# Altium schematic sheet DUT-PowerSupplyMB-Rev2b.SchDoc(DUT-PowerSupplyMB-Rev2b)
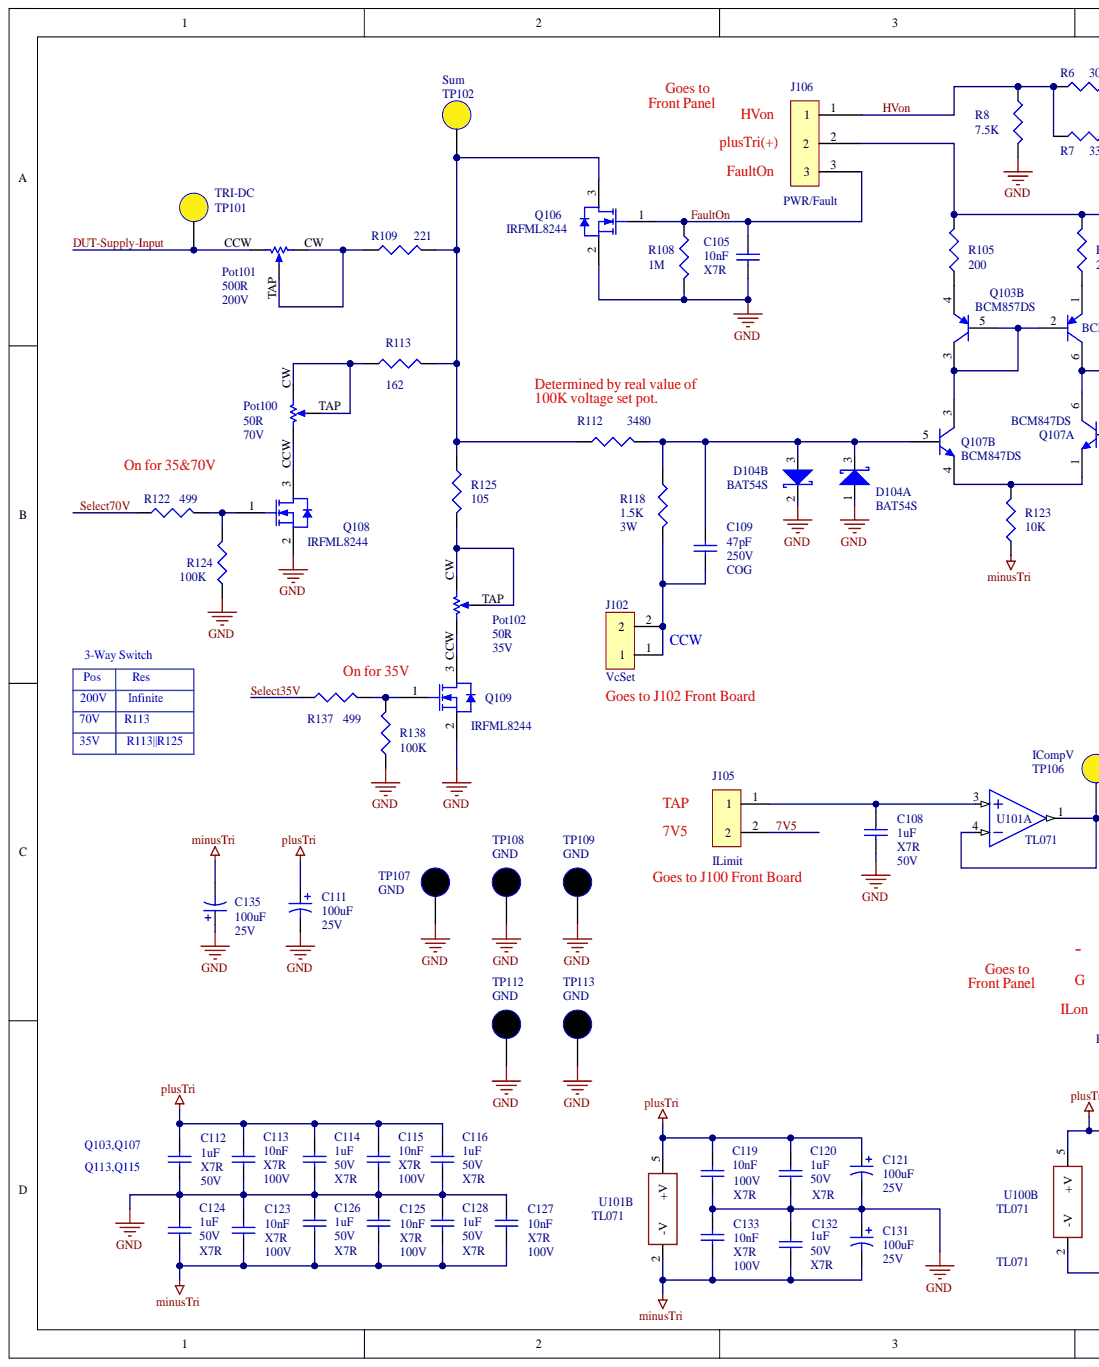
[diagram p0: DUT-PowerSupplyMB-Rev2b.SchDoc, left]
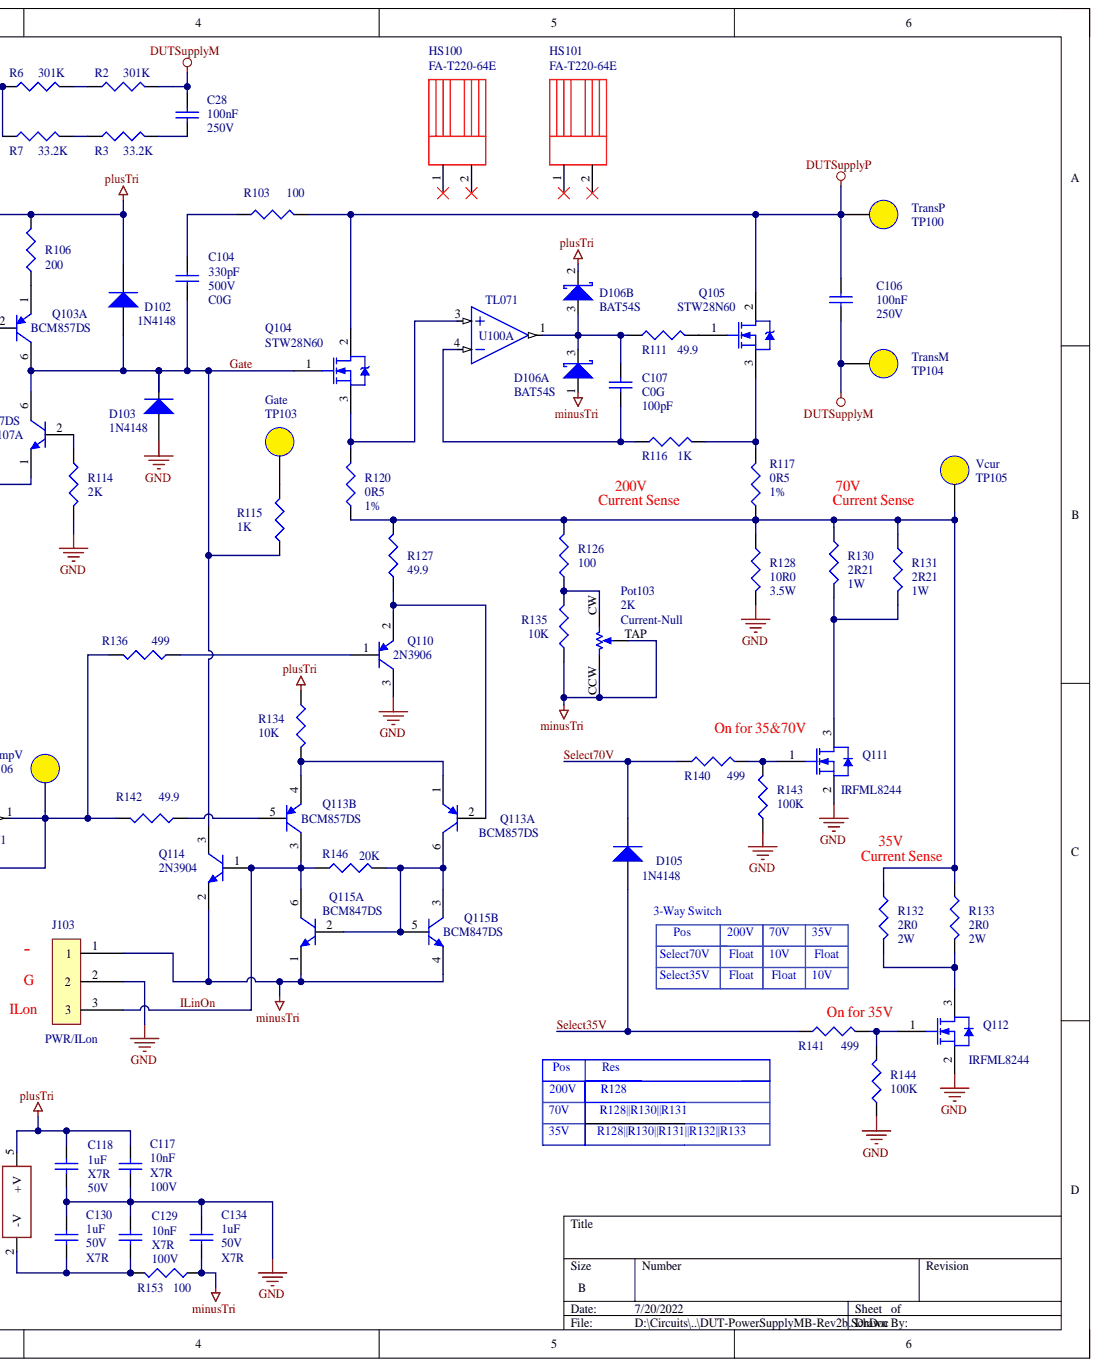
[diagram p1: DUT-PowerSupplyMB-Rev2b.SchDoc, right]

## Parts
C28  Capacitance: 100nF  Voltage Rating: 250V  [CAPC3216M]  (hidden comment: 0.1uF)
C104  330pF  Dielectric: C0G  Voltage Rating: 500V  [CAPC3216M]
C105  10nF  Dielectric: X7R  [CAPC2012M]
C106  Capacitance: 100nF  Voltage Rating: 250V  [CAPC3216M]  (hidden comment: 0.1uF)
C107  100pF  Dielectric: C0G  [CAPC2012M]
C108  1uF  Dielectric: X7R  Voltage: 50V  [CAPC2012M]
C109  47pF  Dialectriv: COG  Voltage Rating: 250V  [CAPC2012M]
C111  100uF  Voltage: 25V  [CAPPR2-5x11]
C112  1uF  Dielectric: X7R  Voltage: 50V  [CAPC2012M]
C113  10nF  Dielectric: X7R  Voltage: 100V  [CAPC2012M]
C114  1uF  Dielectric: X7R  Voltage: 50V  [CAPC2012M]
C115  10nF  Dielectric: X7R  [CAPC2012M]
C116  1uF  Dielectric: X7R  Voltage: 50V  [CAPC2012M]
C117  10nF  Dielectric: X7R  Voltage: 100V  [CAPC2012M]
C118  1uF  Dielectric: X7R  Voltage: 50V  [CAPC2012M]
C119  10nF  Dielectric: X7R  Voltage: 100V  [CAPC2012M]
C120  1uF  Dielectric: X7R  Voltage: 50V  [CAPC2012M]
C121  100uF  Voltage: 25V  [CAPPR2-5x11]
C123  10nF  Dielectric: X7R  Voltage: 100V  [CAPC2012M]
C124  1uF  Dielectric: X7R  Voltage: 50V  [CAPC2012M]
C125  10nF  Dielectric: X7R  [CAPC2012M]
C126  1uF  Dielectric: X7R  Voltage: 50V  [CAPC2012M]
C127  10nF  Dielectric: X7R  Voltage: 100V  [CAPC2012M]
C128  1uF  Dielectric: X7R  Voltage: 50V  [CAPC2012M]
C129  10nF  Dielectric: X7R  [CAPC2012M]
C130  1uF  Dielectric: X7R  Voltage: 50V  [CAPC2012M]
C131  100uF  Voltage: 25V  [CAPPR2-5x11]
C132  1uF  Dielectric: X7R  Voltage: 50V  [CAPC2012M]
C133  10nF  Dielectric: X7R  Voltage: 100V  [CAPC2012M]
C134  1uF  Dielectric: X7R  Voltage: 50V  [CAPC2012M]
C135  100uF  Voltage: 25V  [CAPPR2-5x11]
D102  1N4148  [SOD123]
D103  1N4148  [SOD123]
D104  BAT54S  [SOT23-3M]  (parts A,B)
D105  1N4148  [SOD123]
D106  BAT54S  [SOT23-3M]  (parts A,B)
HS100  value FA-T220-64E  Manufacturer Part Number: FA-T220-64E  [FA-T220]  (hidden comment: 2 Pin Heat Sink)
HS101  value FA-T220-64E  Manufacturer Part Number: FA-T220-64E  [FA-T220]  (hidden comment: 2 Pin Heat Sink)
J102  VcSet  [DTA-100-2]
J103  PWR/ILon  [DTA-100-3]
J105  ILimit  [DTA-100-2]
J106  PWR/Fault  [DTA-100-3]
Pot100  70V  value 50R  Resistance: 50R  [7MMSq-CCW]
Pot101  200V  value 500R  Resistance: 500R  [7MMSq-CCW]
Pot102  35V  value 50R  Resistance: 50R  [7MMSq-CCW]
Pot103  Current-Null  value 2K  [3306P-CCW]
Q103  BCM857DS  [SC-74]  (parts A,B)
Q104  STW28N60  [TO-247]
Q105  STW28N60  [TO-247]
Q106  IRFML8244  [SOT23-3M]
Q107  BCM847DS  [SC-74]  (parts A,B)
Q108  IRFML8244  [SOT23-3M]
Q109  IRFML8244  [SOT23-3M]
Q110  2N3906  [SOT23-3M]
Q111  IRFML8244  [SOT23-3M]
Q112  IRFML8244  [SOT23-3M]
Q113  BCM857DS  [SC-74]  (parts A,B)
Q114  2N3904  [SOT23-3M]
Q115  BCM847DS  [SC-74]  (parts A,B)
R2  value 301K  [RESC2012M]  (hidden comment: 226K)
R3  value 33.2K  [RESC3216M]  (hidden comment: 30.1K)
R6  value 301K  [RESC2012M]  (hidden comment: 226K)
R7  value 33.2K  [RESC3216M]  (hidden comment: 30.1K)
R8  7.5K  [RESC2012M]
R103  100  [RESC3216M]
R105  200  [RESC2012M]
R106  200  [RESC2012M]
R108  1M  [RESC2012M]
R109  value 221  [RESC3216M]  (hidden comment: 200)
R111  49.9  [RESC2012M]
R112  value 3480  [RESC3216M]  (hidden comment: 4.75K)
R113  value 162  [RESC3216M]  (hidden comment: 120)
R114  2K  [RESC2012M]
R115  1K  [RESC2012M]
R116  1K  [RESC2012M]
R117  0R5  Tolerance: 1%  [RESC6432M]
R118  1.5K  Power Rating: 3W  [RESC6432BM]
R120  0R5  Tolerance: 1%  [RESC6432M]
R122  499  [RESC2012M]
R123  10K  [RESC2012M]
R124  100K  [RESC2012M]
R125  value 105  [RESC3216M]  (hidden comment: 49.9)
R126  100  [RESC2012M]
R127  49.9  Resistance Tolerance: 1%  [RESC2012M]
R128  R  value 10R0  Power: 3.5W  [RESC6432BM]
R130  value 2R21  Power Rating: 1W  [RESC6432BM]  (hidden comment: 4R7)
R131  value 2R21  Power Rating: 1W  [RESC6432BM]  (hidden comment: 4R7)
R132  2R0  Power: 2W  [RESC6432BM]
R133  2R0  Power: 2W  [RESC6432BM]
R134  10K  [RESC2012M]
R135  10K  [RESC2012M]
R136  499  [RESC2012M]
R137  499  [RESC2012M]
R138  100K  [RESC2012M]
R140  499  [RESC2012M]
R141  499  [RESC2012M]
R142  49.9  [RESC2012M]
R143  100K  [RESC2012M]
R144  100K  [RESC2012M]
R146  20K  [RESC2012M]
R153  100  [RESC2012M]
TP100  TransP  [TP-.038]
TP101  TRI-DC  [TP-.038]
TP102  Sum  [TP-.038]
TP103  Gate  [TP-.038]
TP104  TransM  [TP-.038]
TP105  Vcur  [TP-.038]
TP106  ICompV  [TP-.038]
TP107  GND  [TP-.038]
TP108  GND  [TP-.038]
TP109  GND  [TP-.038]
TP112  GND  [TP-.038]
TP113  GND  [TP-.038]
U100  value TL071  [SOT95P300X130-5M]  (hidden comment: OPA197)  (parts A,B)
U101  TL071  [SOT95P300X130-5M]  (parts A,B)

## Nets
DUTSupplyM: C28.1 C106.2 R2.2 TP104.1
DUTSupplyP: C106.1 Q104.2 Q105.2 R103.2 TP100.1
GND: C105.2 C108.2 C111.2 C112.2 C113.2 C114.1 C115.1 C116.1 C117.2 C118.2 C119.2 C120.2 C121.2 C123.2 C124.2 C125.2 C126.2 C127.2 C128.2 C129.2 C130.2 C131.1 C132.2 C133.2 C134.2 C135.1 D103.1 D104.1 D104.2 J103.2 Q106.2 Q108.2 Q109.2 Q110.3 Q111.2 Q112.2 R8.1 R108.2 R114.2 R124.1 R128.2 R138.1 R143.1 R144.1 TP107.1 TP108.1 TP109.1 TP112.1 TP113.1
minusTri: C123.1 C124.1 C125.1 C126.1 C127.1 C128.1 C131.2 C132.1 C133.1 C134.1 C135.2 D106.1 J103.1 Pot103.CCW Pot103.TAP Q114.2 Q115.1 Q115.4 R123.2 R135.2 R153.2 U101.2(-V)
plusTri: C111.1 C112.1 C113.1 C114.2 C115.2 C116.2 C117.1 C118.1 C119.1 C120.1 C121.1 D102.2 D106.2 J106.2 R105.2 R106.2 R134.1 U100.5(+V) U101.5(+V)
7V5: J105.2
DUT-Supply-Input: Pot101.CCW TP101.1
FaultOn: C105.1 J106.3 Q106.1 R108.1
Gate: C104.2 D102.1 D103.2 Q103.6 Q104.1 Q107.6 Q114.3 R115.1
HVon: J106.1 R6.1 R7.1 R8.2
ILinOn: J103.3 Q113.3 Q114.1 Q115.6 R146.1
Select35V: D105.1 R137.1 R141.1
Select70V: D105.2 R122.1 R140.1
NetC28_2: C28.2 R3.2
NetC104_1: C104.1 R103.1
NetC107_1: C107.1 R116.1 U100.4
NetC107_2: C107.2 D106.3 R111.1 U100.1
NetC108_1: C108.1 J105.1 U101.3
NetC109_1: C109.1 D104.3 Q107.5 R112.2 R118.1
NetC109_2: C109.2 J102.1 J102.2 R118.2
NetC129_1: C129.1 C130.1 R153.1 U100.2(-V)
NetPot100_CCW: Pot100.CCW Q108.3
NetPot100_CW: Pot100.CW Pot100.TAP R113.1
NetPot101_CW: Pot101.CW Pot101.TAP R109.1
NetPot102_CCW: Pot102.CCW Q109.3
NetPot102_CW: Pot102.CW Pot102.TAP R125.2
NetPot103_CW: Pot103.CW R126.1 R135.1
NetQ103_1: Q103.1 R106.1
NetQ103_2: Q103.2 Q103.3 Q103.5 Q107.3
NetQ103_4: Q103.4 R105.1
NetQ104_3: Q104.3 R120.2 U100.3
NetQ105_1: Q105.1 R111.2
NetQ105_3: Q105.3 R116.2 R117.2
NetQ106_3: Q106.3 R109.2 R112.1 R113.2 R125.1 TP102.1
NetQ107_1: Q107.1 Q107.4 R123.1
NetQ107_2: Q107.2 R114.1
NetQ108_1: Q108.1 R122.2 R124.2
NetQ109_1: Q109.1 R137.2 R138.2
NetQ110_1: Q110.1 R136.1
NetQ110_2: Q110.2 Q113.2 R127.1
NetQ111_1: Q111.1 R140.2 R143.2
NetQ111_3: Q111.3 R130.2 R131.2
NetQ112_1: Q112.1 R141.2 R144.2
NetQ112_3: Q112.3 R132.2 R133.2
NetQ113_1: Q113.1 Q113.4 R134.2
NetQ113_5: Q113.5 R142.1
NetQ113_6: Q113.6 Q115.2 Q115.3 Q115.5 R146.2
NetR2_1: R2.1 R6.2
NetR3_1: R3.1 R7.2
NetR115_2: R115.2 TP103.1
NetR117_1: R117.1 R120.1 R126.2 R127.2 R128.1 R130.1 R131.1 R132.1 R133.1 TP105.1
NetR136_2: R136.2 R142.2 TP106.1 U101.1 U101.4

Not connected: HS100 1 2; HS101 1 2
